# Altium schematic sheet PFC_Stage.SchDoc
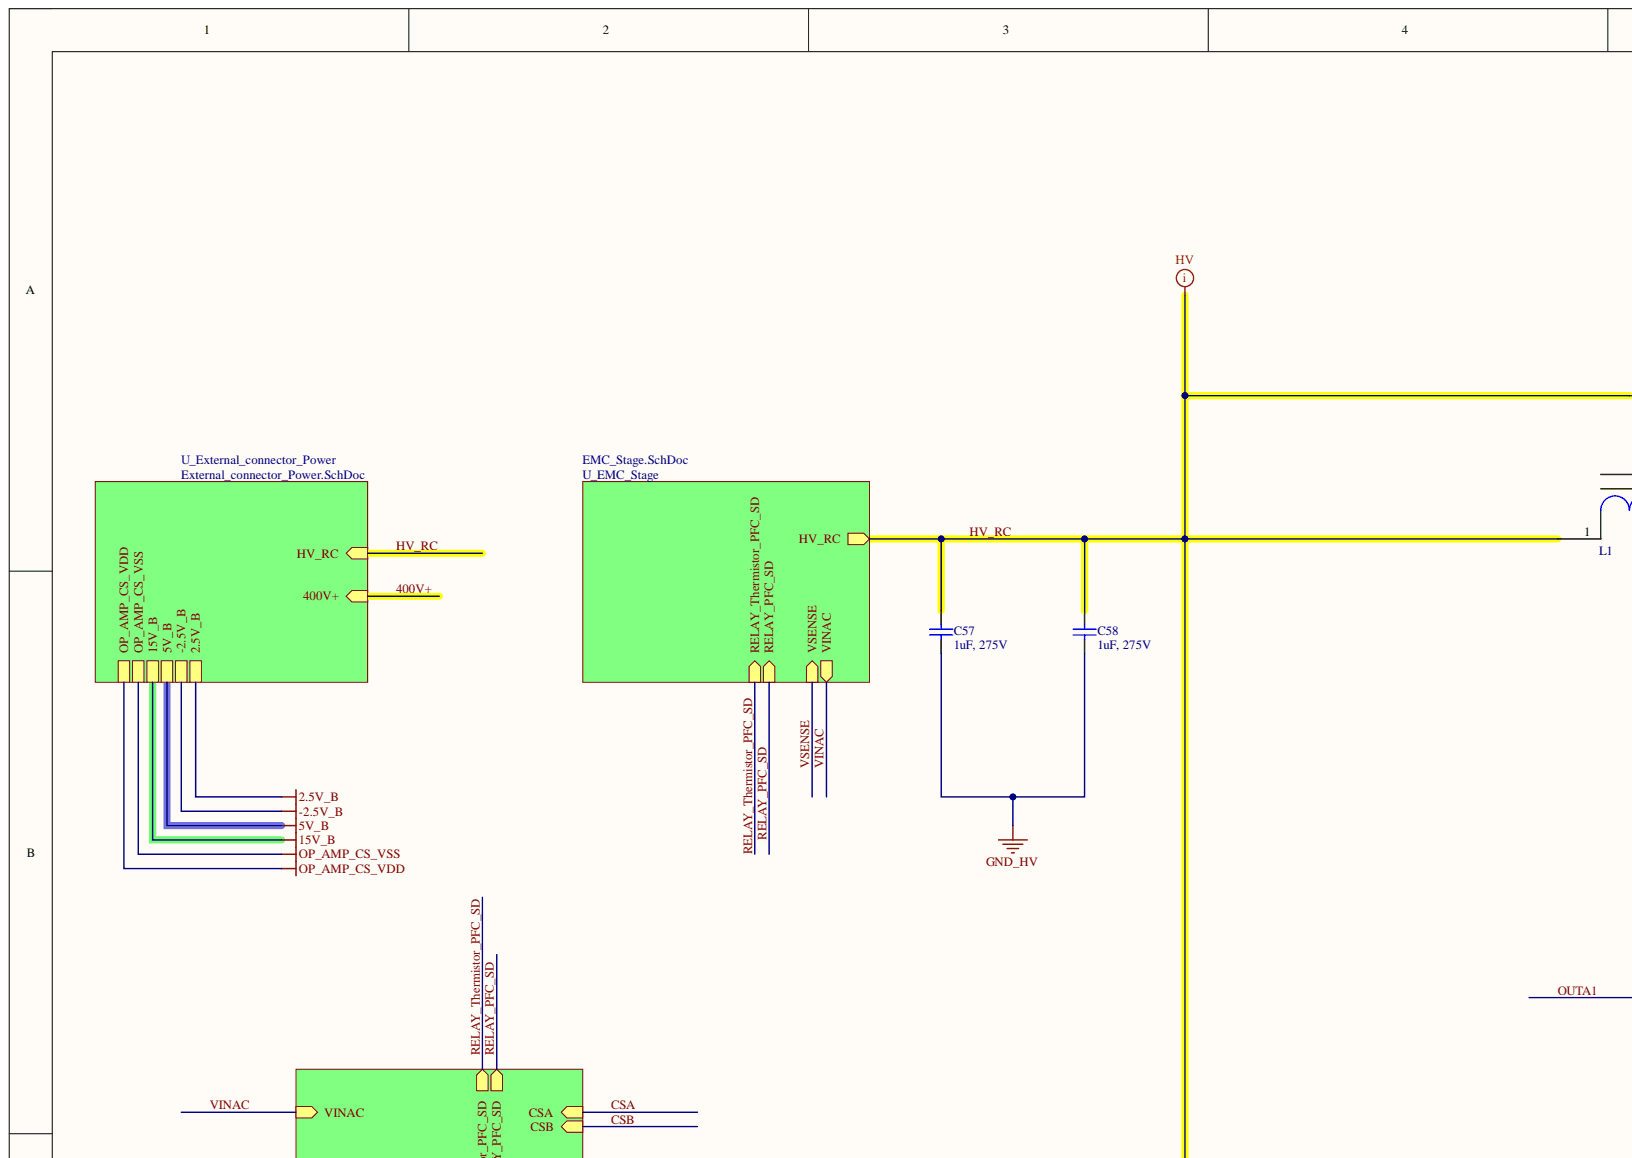
[diagram p0: PFC_Stage.SchDoc, top left]
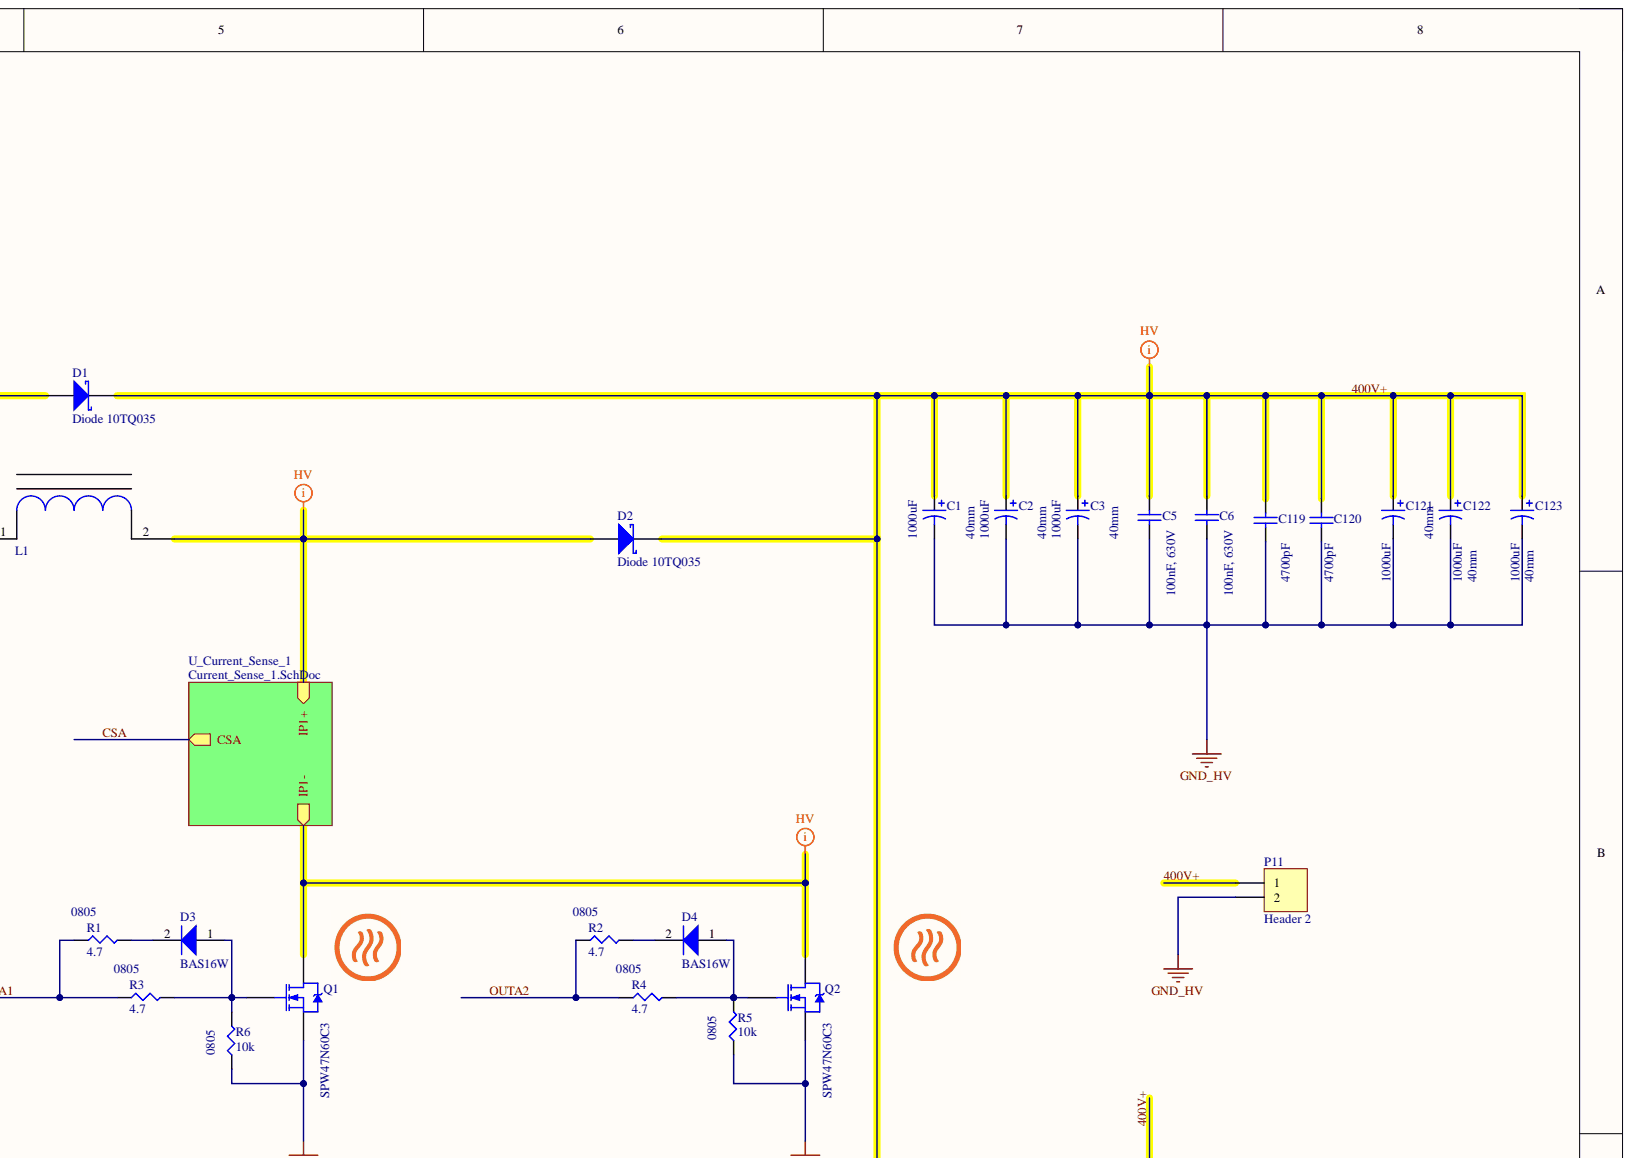
[diagram p1: PFC_Stage.SchDoc, top right]
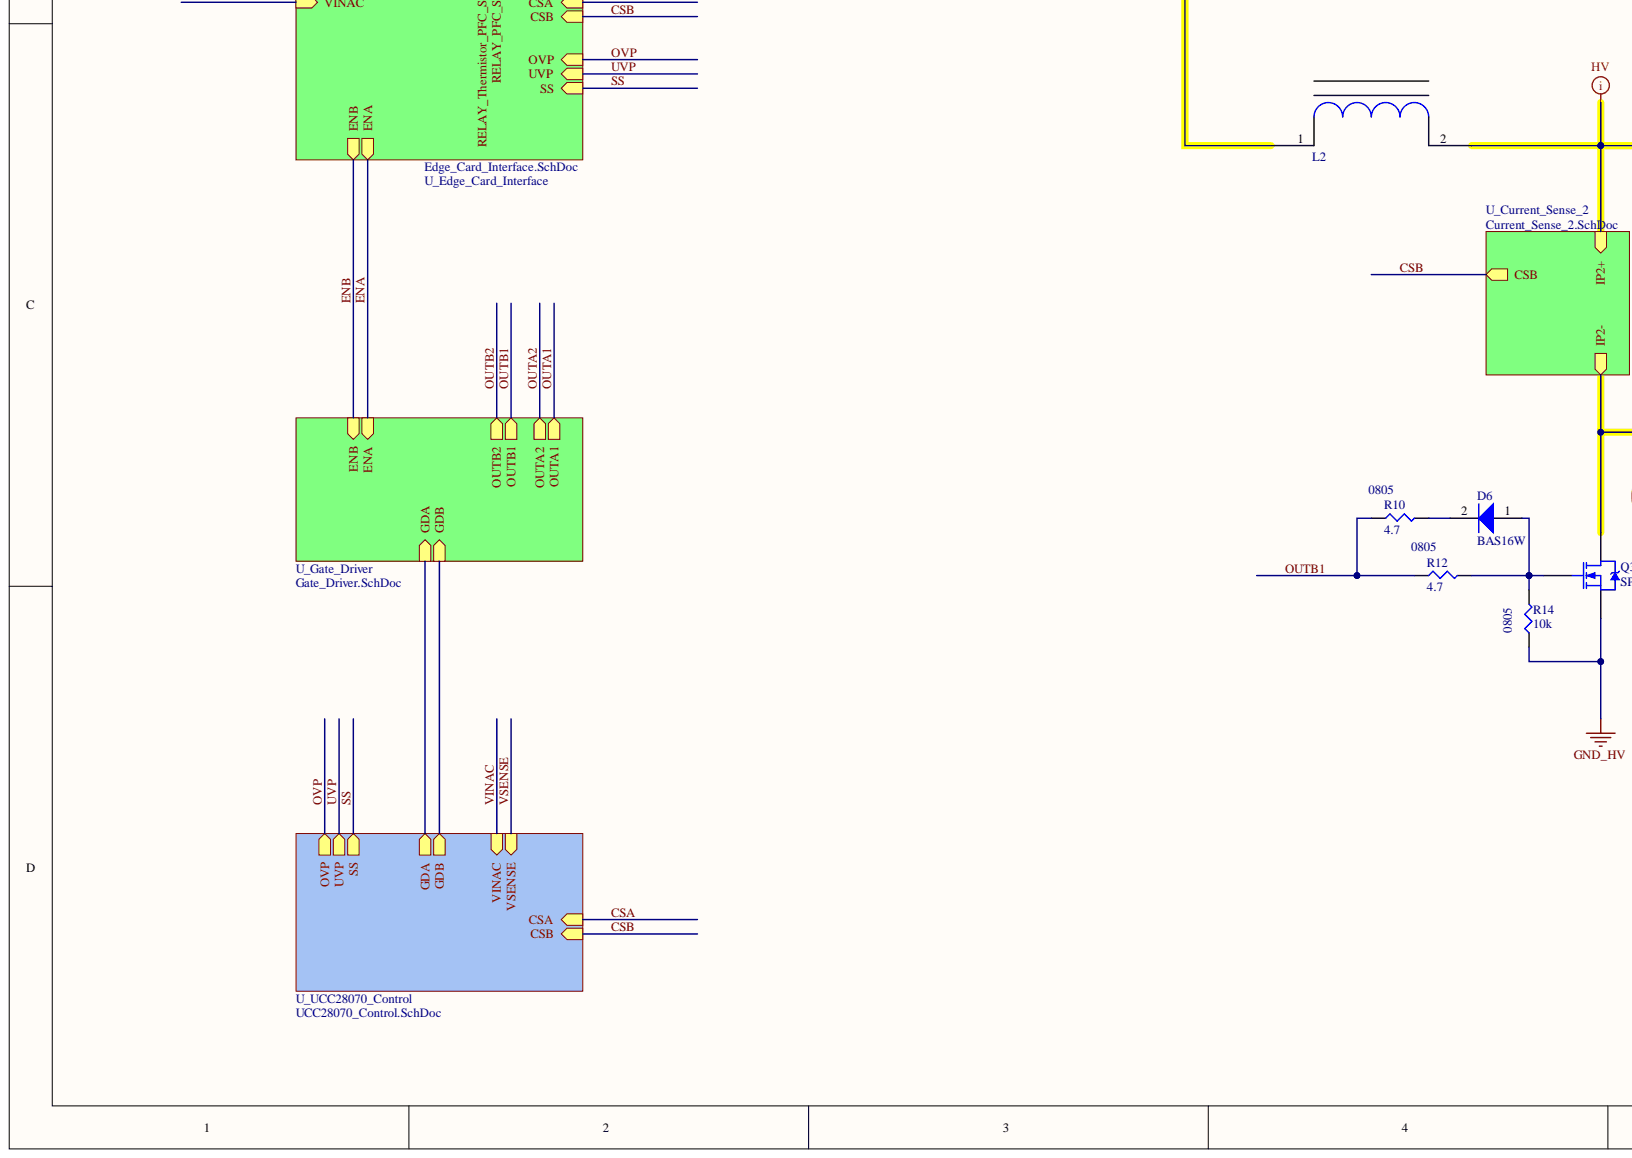
[diagram p2: PFC_Stage.SchDoc, bottom left]
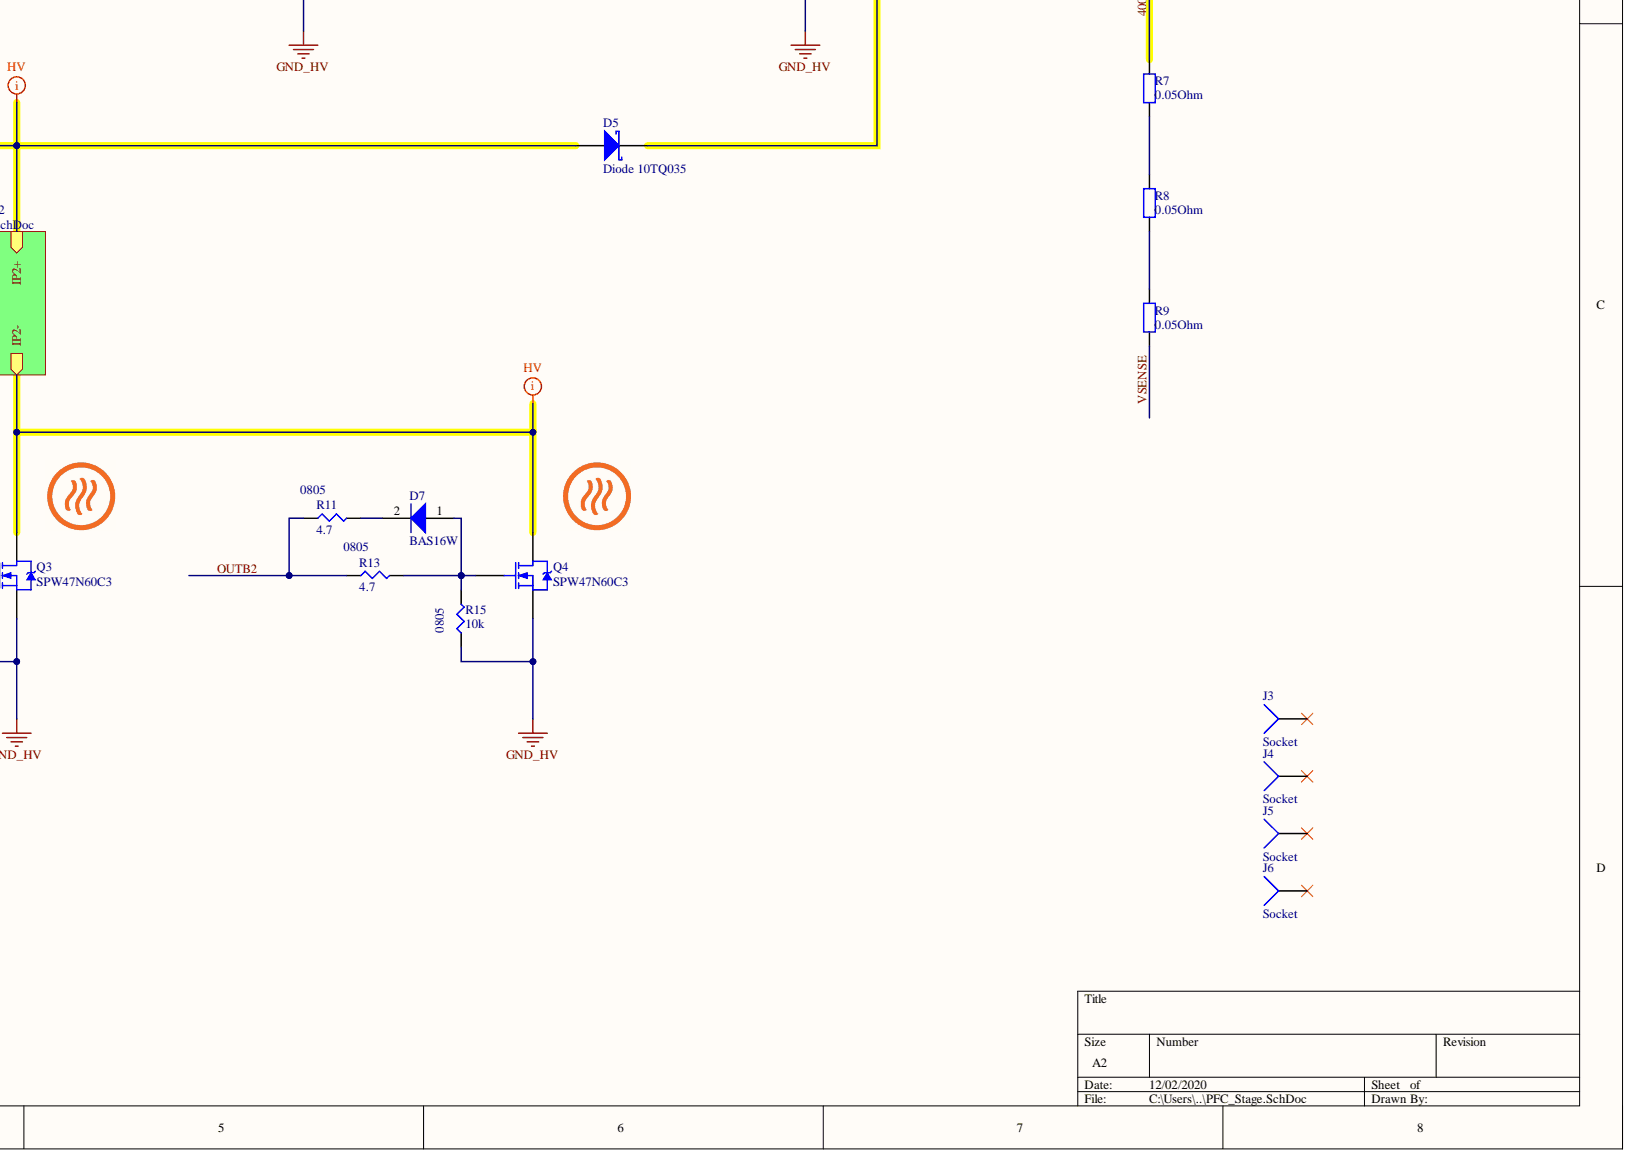
[diagram p3: PFC_Stage.SchDoc, bottom right]

## Parts
C1  40mm  value 1000uF  [450V_40mm_10mm]
C2  40mm  value 1000uF  [450V_40mm_10mm]
C3  40mm  value 1000uF  [450V_40mm_10mm]
C5  100nF, 630V  [L18_W6_H12_P15]
C6  100nF, 630V  [L18_W6_H12_P15]
C57  1uF, 275V  [L26_W11_H20_P22.5_CS]
C58  1uF, 275V  [L26_W11_H20_P22.5_CS]
C119  value 4700pF  [VY1472M61Y5UC63V0]  (hidden comment: VY1472M61Y5UC63V0)
C120  value 4700pF  [VY1472M61Y5UC63V0]  (hidden comment: VY1472M61Y5UC63V0)
C121  40mm  value 1000uF  [450V_40mm_10mm]
C122  40mm  value 1000uF  [450V_40mm_10mm]
C123  40mm  value 1000uF  [450V_40mm_10mm]
D1  Diode 10TQ035  [INF-PG-TO247-2-MOD]
D2  Diode 10TQ035  [INF-PG-TO247-2-MOD]
D3  BAS16W  [SODFL250X110-2N]
D4  BAS16W  [SODFL250X110-2N]
D5  Diode 10TQ035  [INF-PG-TO247-2-MOD]
D6  BAS16W  [SODFL250X110-2N]
D7  BAS16W  [SODFL250X110-2N]
J3  Socket  [Mounting_hole_3mm]
J4  Socket  [Mounting_hole_3mm]
J5  Socket  [Mounting_hole_3mm]
J6  Socket  [Mounting_hole_3mm]
L1  Transformer_Inductor  [PFC_Toroid_MPP]  (value not drawn)
L2  Transformer_Inductor  [PFC_Toroid_MPP]  (value not drawn)
P11  Header 2  [Barrier_Strip_2]
Q1  SPW47N60C3  [INF-PG-TO247-3-44]
Q2  SPW47N60C3  [INF-PG-TO247-3-44]
Q3  SPW47N60C3  [INF-PG-TO247-3-44]
Q4  SPW47N60C3  [INF-PG-TO247-3-44]
R1  4.7  Supplier Device Package: 0805  [SMD-0805-RES]
R2  4.7  Supplier Device Package: 0805  [SMD-0805-RES]
R3  4.7  Supplier Device Package: 0805  [SMD-0805-RES]
R4  4.7  Supplier Device Package: 0805  [SMD-0805-RES]
R5  10k  Supplier Device Package: 0805  [SMD-0805-RES]
R6  10k  Supplier Device Package: 0805  [SMD-0805-RES]
R7  0.05Ohm  [WRIS-KSKE_1206_H=0.6mm]
R8  0.05Ohm  [WRIS-KSKE_1206_H=0.6mm]
R9  0.05Ohm  [WRIS-KSKE_1206_H=0.6mm]
R10  4.7  Supplier Device Package: 0805  [SMD-0805-RES]
R11  4.7  Supplier Device Package: 0805  [SMD-0805-RES]
R12  4.7  Supplier Device Package: 0805  [SMD-0805-RES]
R13  4.7  Supplier Device Package: 0805  [SMD-0805-RES]
R14  10k  Supplier Device Package: 0805  [SMD-0805-RES]
R15  10k  Supplier Device Package: 0805  [SMD-0805-RES]

## Nets
GND_HV: C1.2 C2.2 C3.2 C5.1 C6.1 C57.1 C58.1 C119.1 C120.1 C121.2 C122.2 C123.2 P11.2 Q1.3 Q2.3 Q3.3 Q4.3 R5.1 R6.1 R14.1 R15.1
400V+: C1.1 C2.1 C3.1 C5.2 C6.2 C119.2 C120.2 C121.1 C122.1 C123.1 D1.1 D2.1 D5.1 P11.1 R7.2
HV_RC: C57.2 C58.2 D1.3 L1.1 L2.1
OUTA1: R1.1 R3.1
OUTA2: R2.1 R4.1
OUTB1: R10.1 R12.1
OUTB2: R11.1 R13.1
VSENSE: R9.1
NetD2_3: D2.3 L1.2
NetD3_1: D3.1 Q1.1 R3.2 R6.2
NetD3_2: D3.2 R1.2
NetD4_1: D4.1 Q2.1 R4.2 R5.2
NetD4_2: D4.2 R2.2
NetD5_3: D5.3 L2.2
NetD6_1: D6.1 Q3.1 R12.2 R14.2
NetD6_2: D6.2 R10.2
NetD7_1: D7.1 Q4.1 R13.2 R15.2
NetD7_2: D7.2 R11.2
NetJ3_1: J3.1
NetJ4_1: J4.1
NetJ5_1: J5.1
NetJ6_1: J6.1
NetQ1_2: Q1.2 Q2.2
NetQ3_2: Q3.2 Q4.2
NetR7_1: R7.1 R8.2
NetR8_1: R8.1 R9.2
2.5V_B:
5V_B:
15V_B:
-2.5V_B:
CSA:
CSB:
ENA:
ENB:
GDA:
GDB:
OP_AMP_CS_VDD:
OP_AMP_CS_VSS:
OVP:
RELAY_PFC_SD:
RELAY_Thermistor_PFC_SD:
SS:
UVP:
VINAC:

## Library data of the parts
C1-C3 C121-C123 = Cap Pol1: Polarized Capacitor (Radial); Component Kind: Standard; LatestRevisionDate: 17-Jul-2002; LatestRevisionNote: Re-released for DXP Platform.; Library Name: Miscellaneous Devices.IntLib; PackageDescription: Polarized Capacitor (Radial); 2 Leads; PackageReference: RB7.6-15; Published: 8-Jun-2000; Signal Integrity: Cap Pol; Simulation: CAP; Publisher: Altium Limited
C5 C6 = 890303425004CS: WCAP-FTBE THT Film Capacitors, 100nF, 630VDC; Dissipation Factor@1kHz: 1%; Dissipation Factor@10kHz: 1.4%; Dissipation Factor@100kHz: 3.2%; Insulation Resistance: 9000MOhm; Pitch: 15mm; Rate of Voltage Rise: 28V/us; Technical Reference: MPSP015104K630DCPP45004; Capacitance: 100nF; Component Kind: Standard; ComponentLink1Description: Manufacturer; ComponentLink1URL: http://www.we-online.de; ComponentLink2Description: Datasheet; ComponentLink2URL: https://katalog.we-online.com/pbs/datasheet/890303425004CS.pdf; Height: 12mm; Length: 18mm; Library Name: Altium_WCAP-FTBE_rev19a.IntLib; Mounting Technology: THT; Operating Temperature: -40 to +85degC; Packaging: Carton; Part Number: 890303425004CS; Published Date: August 2019; Rated Voltage: 630VDC; Width: 6mm; Publisher: Wurth Elektronik
C57 C58 = 890324026027CS: WCAP-FTX2 THT Film Capacitors, 1uF, 275VAC; Dissipation Factor@1kHz: 0.1%; Dissipation Factor@10kHz: 0.7%; Insulation Resistance: 10000Ohm x F; Pitch: 22.5mm; Rate of Voltage Rise: 170V/us; Technical Reference: MX2P225105K275ACPP36004; Capacitance: 1uF; Component Kind: Standard; ComponentLink1Description: Manufacturer; ComponentLink1URL: http://www.we-online.de; ComponentLink2Description: Datasheet; ComponentLink2URL: https://katalog.we-online.com/pbs/datasheet/890324026027CS.pdf; Dissipation Factor@100kHz: -; Height: 20mm; Length: 26mm; Library Name: Altium_WCAP-FTX2_rev19a.IntLib; Mounting Technology: THT; Operating Temperature: -40 to +105degC; Packaging: Bulk and Carton; Part Number: 890324026027CS; Published Date: August 2019; Rated Voltage: 275VAC; Width: 11mm; Publisher: Wurth Elektronik
C119 C120 = VY1472M61Y5UC63V0: Capacitor; Component Kind: Standard; LatestRevisionDate: 17-Jul-2002; LatestRevisionNote: Re-released for DXP Platform.; PackageDescription: Radial Cap, Thru-Hole; 2 Leads; 0.3 in Pin Spacing; PackageReference: RAD-0.3; Published: 8-Jun-2000; Library Name: PFC_Stage.SchLib; Publisher: Altium Limited
D1 D2 D5 = Diode 10TQ035: Schottky Rectifier; Note: Terminal 2 is the TAB and is electrically connected to the cathode; Component Kind: Standard; ComponentLink1Description: Manufacturer Link; ComponentLink1URL: http://www.irf.com/; ComponentLink2Description: Datasheet; ComponentLink2URL: http://www.irf.com/product-info/datasheets/data/10tq.pdf; DatasheetVersion: PD-2.057B; LatestRevisionDate: 17-Jul-2002; LatestRevisionNote: Re-released for DXP Platform.; Library Name: Miscellaneous Devices.IntLib; PackageDescription: TO, Thru-Hole, Vertical, Heatsink Mounted; 3 In-Line Leads (1 Absent); Pitch 2.54 mm; PackageReference: TO-220AC; PackageVersion: Feb-1998; Published: 8-Jun-2000; Simulation: 10TQ035; Publisher: Altium Limited
D3 D4 D6 D7 = BAS16_SOD: Silicon Switching Diode, SOT323, Reel, Green; Manufacturer: Infineon; Configuration: Single; Green: TRUE; IF (max): 250Â mA; IR (max): 100Â nA; trr (max): 4Â ns; VBR (min): 85Â V; VF (max): 1.25Â V; VR (max): 80Â V; Component Kind: Standard; ComponentLink1Description: Manufacturer URL; ComponentLink1URL: http://www.infineon.com/; ComponentLink2Description: Datasheet; ComponentLink2URL: http://www.infineon.com/dgdl/bas16series.pdf?folderId=db3a30431400ef6801141b5844e103ea&fileId=db3a30431400ef6801141b93811b03ff; ComponentLink3Description: Package Specification; ComponentLink3URL: http://www.infineon.com/dgdl/SOT323-Package_Overview.pdf?folderId=db3a30431936bc4b0119533e1dcb3c92&fileId=db3a30431936bc4b011953495f283cb1; Halogen-free: TRUE; Mounting Technology: Surface Mount; PackageDescription: 3-Pin Small Outline Transistor Package, 1.25 x 2 mm Body, 0.65 mm Pitch; PackageReference: SOT323; PartNumber: BAS16W; Library Name: PFC_Stage.SchLib
P11 = Header 2: Header, 2-Pin; Component Kind: Standard; LatestRevisionDate: 17-Jul-2002; LatestRevisionNote: Re-released for DXP Platform.; Library Name: Miscellaneous Connectors.IntLib; Signal Integrity: Connector; Publisher: Altium Limited
Q1-Q4 = NMOS-2: N-Channel Power MOSFET; Note: Pin 2 is electrically connected to pin 4.; Component Kind: Standard; ComponentLink1Description: Manufacturer Link; ComponentLink1URL: http://www.irf.com/; DatasheetVersion: PD-9.814; LatestRevisionDate: 17-Jul-2002; LatestRevisionNote: Re-released for DXP Platform.; Library Name: Miscellaneous Devices.IntLib; PackageDescription: TO, Thru-Hole, Vertical, Heatsink Mounted; 3 In-Line Leads; Pitch 2.54 mm; PackageReference: TO-220AB; PackageVersion: Feb-1997; Published: 8-Jun-2000; Simulation: IRF1010; Publisher: Altium Limited
R1-R4 R10-R13 = ERJ-6BQF4R7V: RES SMD 4.7 OHM 1% 1/3W 0805; Manufacturer: Panasonic Electronic Components; @ qty: 1500; Factory Stock: 0; Minimum Quantity: 1; Power (Watts): 0.333W, 1/3W; Temperature Coefficient: ±200ppm/°C; Unit Price (USD): 0.07013; Component Kind: Standard; Composition: Thick Film; Datasheets: https://industrial.panasonic.com/cdbs/www-data/pdf/RDA0000/AOA0000C239.pdf; Digi-Key Part Number: P15995CT-ND; Failure Rate: -; Features: Automotive AEC-Q200, Current Sense; Height - Seated (Max): 0.028" (0.70mm); Image: //media.digikey.com/Renders/Panasonic%20Renders/ERJ-0805%20Pkg.jpg; Library Name: Panasonic_ERJ_0805.IntLib; Number of Terminations: 2; Operating Temperature: -55°C ~ 125°C; Package / Case: 0805 (2012 Metric); Packaging: Cut Tape (CT); Part Number: ERJ-6BQF4R7V; Part Status: Active; Quantity Available: 9150; Series: ERJ; Size / Dimension: 0.079" L x 0.049" W (2.00mm x 1.25mm); Tolerance: ±1%; Value: 4.7
R5 R6 R14 R15 = ERJ-6ENF1002V: RES SMD 10K OHM 1% 1/8W 0805; Manufacturer: Panasonic Electronic Components; @ qty: 1500; Factory Stock: 0; Minimum Quantity: 1; Power (Watts): 0.125W, 1/8W; Temperature Coefficient: ±100ppm/°C; Unit Price (USD): 0.00729; Component Kind: Standard; Composition: Thick Film; Datasheets: http://industrial.panasonic.com/www-cgi/jvcr13pz.cgi?E+PZ+3+AOA0002+ERJ6ENF1002V+7+WW; Digi-Key Part Number: P10.0KCCT-ND; Failure Rate: -; Features: Automotive AEC-Q200; Height - Seated (Max): 0.028" (0.70mm); Image: //media.digikey.com/Renders/Panasonic%20Renders/ERJ-0805%20Pkg.jpg; Library Name: Panasonic_ERJ_0805.IntLib; Number of Terminations: 2; Operating Temperature: -55°C ~ 155°C; Package / Case: 0805 (2012 Metric); Packaging: Cut Tape (CT); Part Number: ERJ-6ENF1002V; Part Status: Active; Quantity Available: 3985614; Series: ERJ; Size / Dimension: 0.079" L x 0.049" W (2.00mm x 1.25mm); Tolerance: ±1%; Value: 10k
R7-R9 = 560050332001: WRIS-KSKE Thick Film Resistors, size 1206, 0.05Ohm, +/-1%, 0.5W; Rated Current: 3.16A; Technical Reference: KE1206R050F102LAATP0000; Temperature Coefficient of Resistance: 250ppm/degC; Component Kind: Standard; ComponentLink1Description: Manufacturer; ComponentLink1URL: http://www.we-online.de; ComponentLink2Description: Datasheet; ComponentLink2URL: https://katalog.we-online.com/pbs/datasheet/560050332001.pdf; Height: 0.6mm; Length: 3.1mm; Library Name: Altium_WRIS-KSKE_rev19a.IntLib; Mounting Technology: SMT; Operating Temperature: -55 to +155degC; Packaging: Tape and Reel; Part Number: 560050332001; Published Date: September 2019; Rated Power: 0.5W; Resistance: 0.05Ohm; Resistance Tolerance: +/-1%; Size: 1206; Width: 1.6mm; Publisher: Wurth Elektronik
J3-J6 = Socket: Component Kind: Standard; Description: Socket; LatestRevisionDate: 17-Jul-2002; LatestRevisionNote: Re-released for DXP Platform.; Library Name: PFC_Stage.SchLib; Publisher: Altium Limited
L1 L2 = Transformer_Inductor: Component Kind: Standard; Library Name: PFC_Stage.SchLib
